# Altium schematic sheet Sheet1.SchDoc
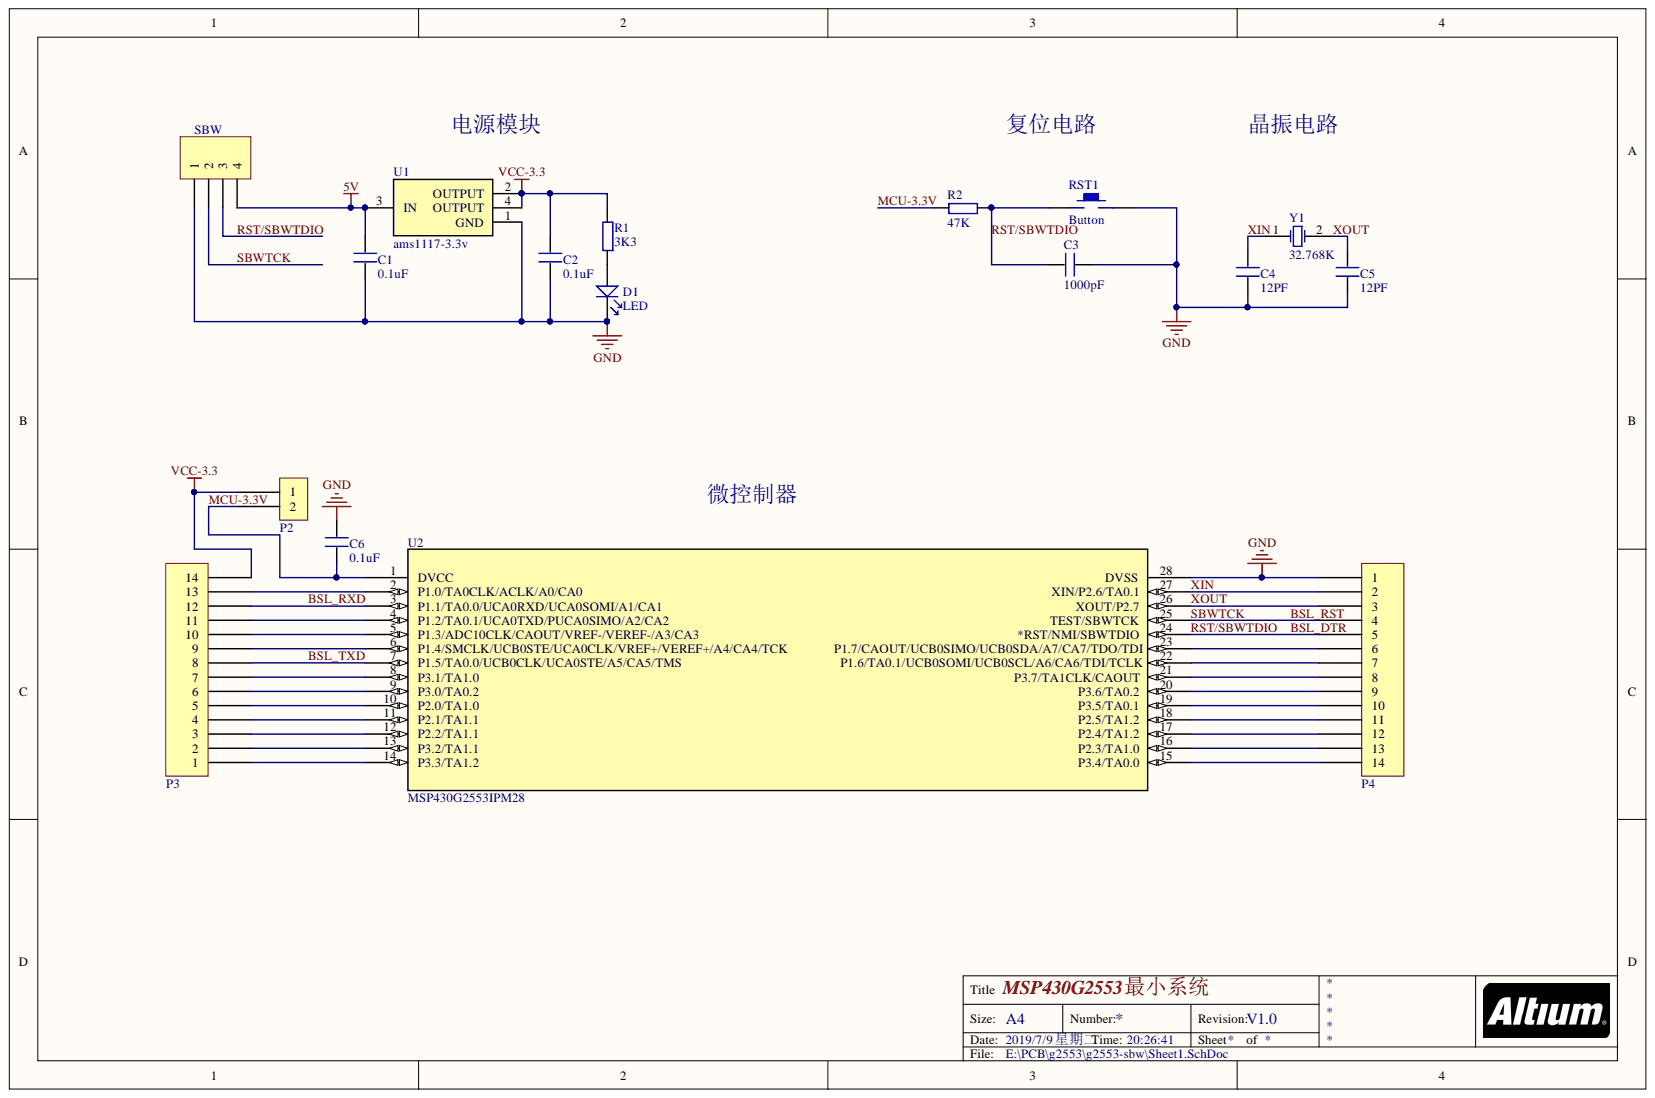
[diagram p0: Sheet1.SchDoc]

## Parts
C1  0.1uF  [0603]
C2  0.1uF  [0603]
C3  1000pF  [0603]
C4  12PF  [0402]
C5  12PF  [0402]
C6  0.1uF  [0603]
D1  LED  [LED1]
P2  H-2P  [PIN-2]  (value not drawn)
P3  H-14P  [H-14P]  (value not drawn)
P4  H-14P  [H-14P]  (value not drawn)
R1  3K3  [0603]
R2  47K  [0603]
RST1  Button  [TSW SMD-3*6*2.5]
SBW  Header 4  [HDR1X4]  (value not drawn)
U1  ams1117-3.3v  [DCY0004A_M]
U2  MSP430G2553IPM28  [PW28]
Y1  32.768K  [OSC-2x6-WI-B]

## Nets
5V: C1.2 SBW.4 U1.3(IN)
GND: C1.1 C2.1 C3.1 C4.1 C5.1 C6.1 D1.2 P4.1 RST1.2 SBW.1 U1.1(GND) U2.28(DVSS)
VCC-3.3: C2.2 P2.1 P3.14 R1.2 U1.2(OUTPUT) U1.4(OUTPUT)
BSL_DTR: C3.2 P4.5 R2.2 RST1.1 SBW.3 U2.24(*RST/NMI/SBWTDIO)
BSL_RST: P4.4 SBW.2 U2.25(TEST/SBWTCK)
BSL_RXD: P3.12 U2.3(P1.1/TA0.0/UCA0RXD/UCA0SOMI/A1/CA1)
BSL_TXD: P3.8 U2.7(P1.5/TA0.0/UCB0CLK/UCA0STE/A5/CA5/TMS)
MCU-3.3V: C6.2 P2.2 R2.1 U2.1(DVCC)
XIN: C4.2 P4.2 U2.27(XIN/P2.6/TA0.1) Y1.1
XOUT: C5.2 P4.3 U2.26(XOUT/P2.7) Y1.2
NetD1_1: D1.1 R1.1
NetP3_1: P3.1 U2.14(P3.3/TA1.2)
NetP3_2: P3.2 U2.13(P3.2/TA1.1)
NetP3_3: P3.3 U2.12(P2.2/TA1.1)
NetP3_4: P3.4 U2.11(P2.1/TA1.1)
NetP3_5: P3.5 U2.10(P2.0/TA1.0)
NetP3_6: P3.6 U2.9(P3.0/TA0.2)
NetP3_7: P3.7 U2.8(P3.1/TA1.0)
NetP3_9: P3.9 U2.6(P1.4/SMCLK/UCB0STE/UCA0CLK/VREF+/VEREF+/A4/CA4/TCK)
NetP3_10: P3.10 U2.5(P1.3/ADC10CLK/CAOUT/VREF-/VEREF-/A3/CA3)
NetP3_11: P3.11 U2.4(P1.2/TA0.1/UCA0TXD/PUCA0SIMO/A2/CA2)
NetP3_13: P3.13 U2.2(P1.0/TA0CLK/ACLK/A0/CA0)
NetP4_6: P4.6 U2.23(P1.7/CAOUT/UCB0SIMO/UCB0SDA/A7/CA7/TDO/TDI)
NetP4_7: P4.7 U2.22(P1.6/TA0.1/UCB0SOMI/UCB0SCL/A6/CA6/TDI/TCLK)
NetP4_8: P4.8 U2.21(P3.7/TA1CLK/CAOUT)
NetP4_9: P4.9 U2.20(P3.6/TA0.2)
NetP4_10: P4.10 U2.19(P3.5/TA0.1)
NetP4_11: P4.11 U2.18(P2.5/TA1.2)
NetP4_12: P4.12 U2.17(P2.4/TA1.2)
NetP4_13: P4.13 U2.16(P2.3/TA1.0)
NetP4_14: P4.14 U2.15(P3.4/TA0.0)

## Library data of the parts
C1-C6 = CAP: Component Kind: Standard; Library Name: TI.SchLib
R1 R2 = RES: Component Kind: Standard; Library Name: TI.SchLib
SBW = Header 4: Header, 4-Pin; Component Kind: Standard; LatestRevisionDate: 17-Jul-2002; LatestRevisionNote: Re-released for DXP Platform.; Library Name: Miscellaneous Connectors.IntLib; Signal Integrity: Connector; Publisher: Altium Limited
U1 = ams1117-3.3v: 3.3V; Component Kind: Standard; Library Name: My-lib.SchLib
Y1 = XTAL: Component Kind: Standard; Library Name: My-lib.SchLib
D1 = LED: Component Kind: Standard; Library Name: TI.SchLib
P2 = H-2P: Component Kind: Standard; Library Name: TI.SchLib
P3 P4 = H-14P: Component Kind: Standard; Library Name: TI.SchLib
RST1 = Button: Component Kind: Standard; Library Name: My-lib.SchLib
U2 = MSP430G2553IPM28: Component Kind: Standard; Library Name: TI.SchLib
